# Altium schematic sheet 基于STM32的DDS信号源的设计实例.SchDoc
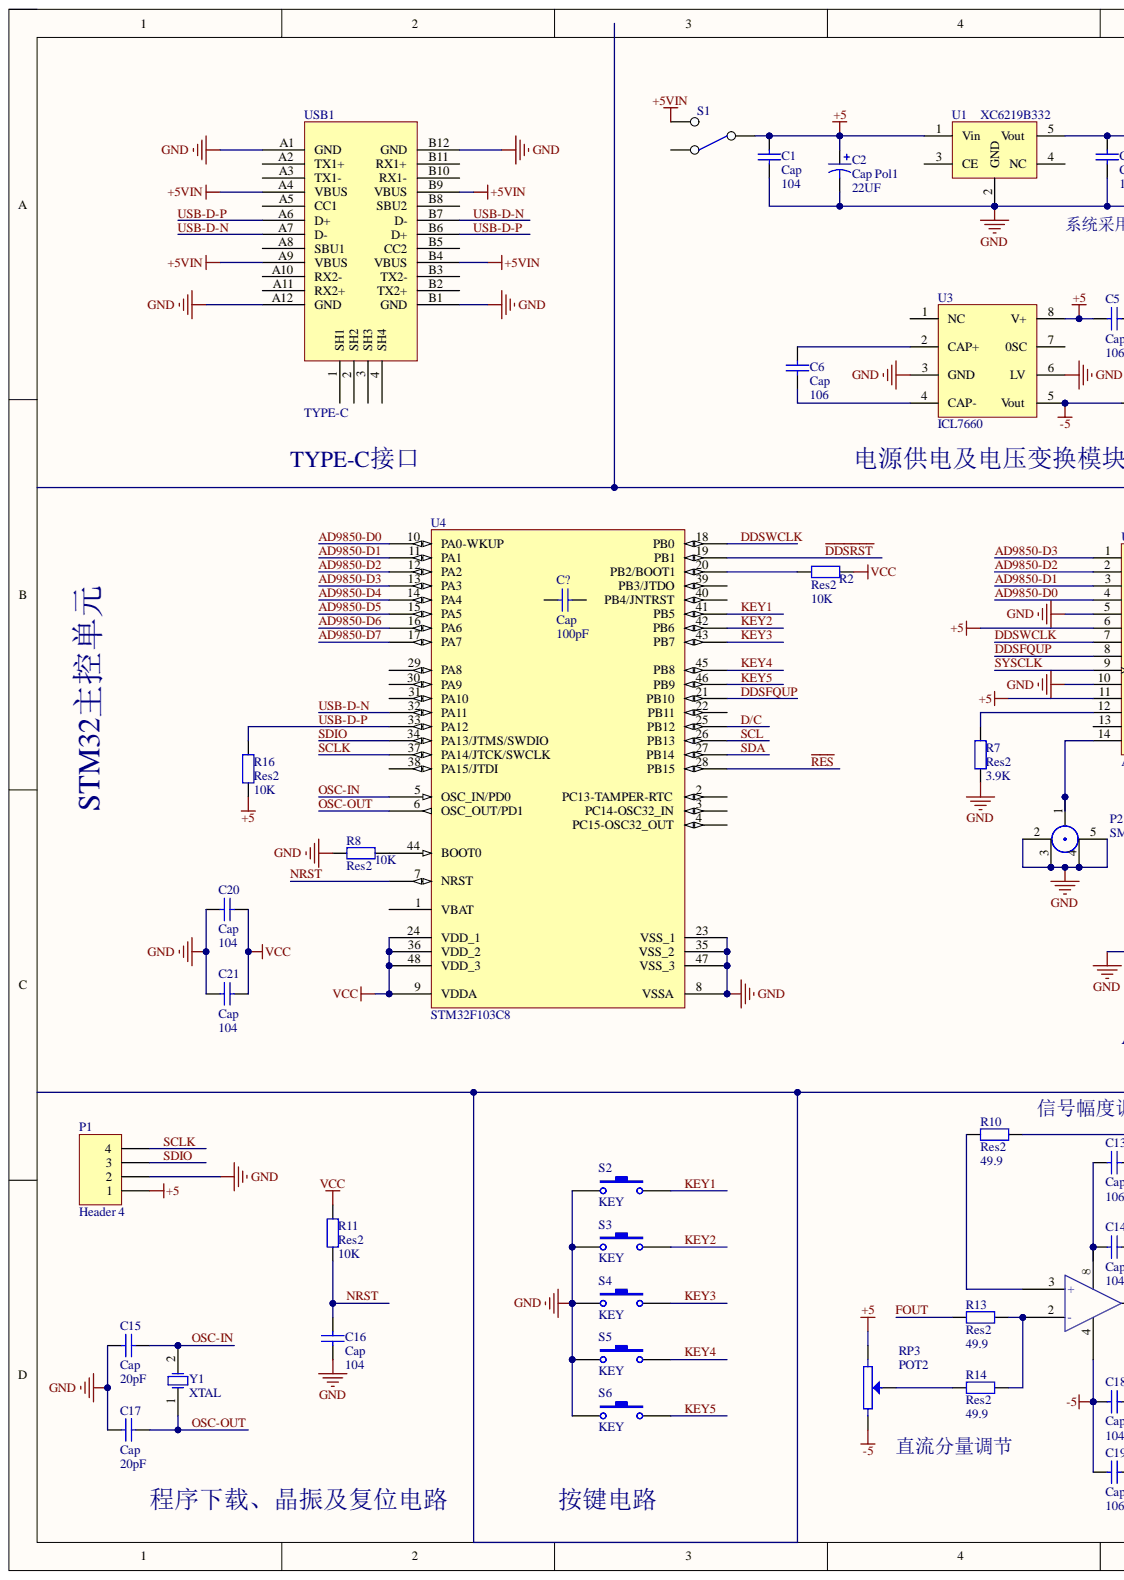
[diagram p0: 基于STM32的DDS信号源的设计实例.SchDoc, left]
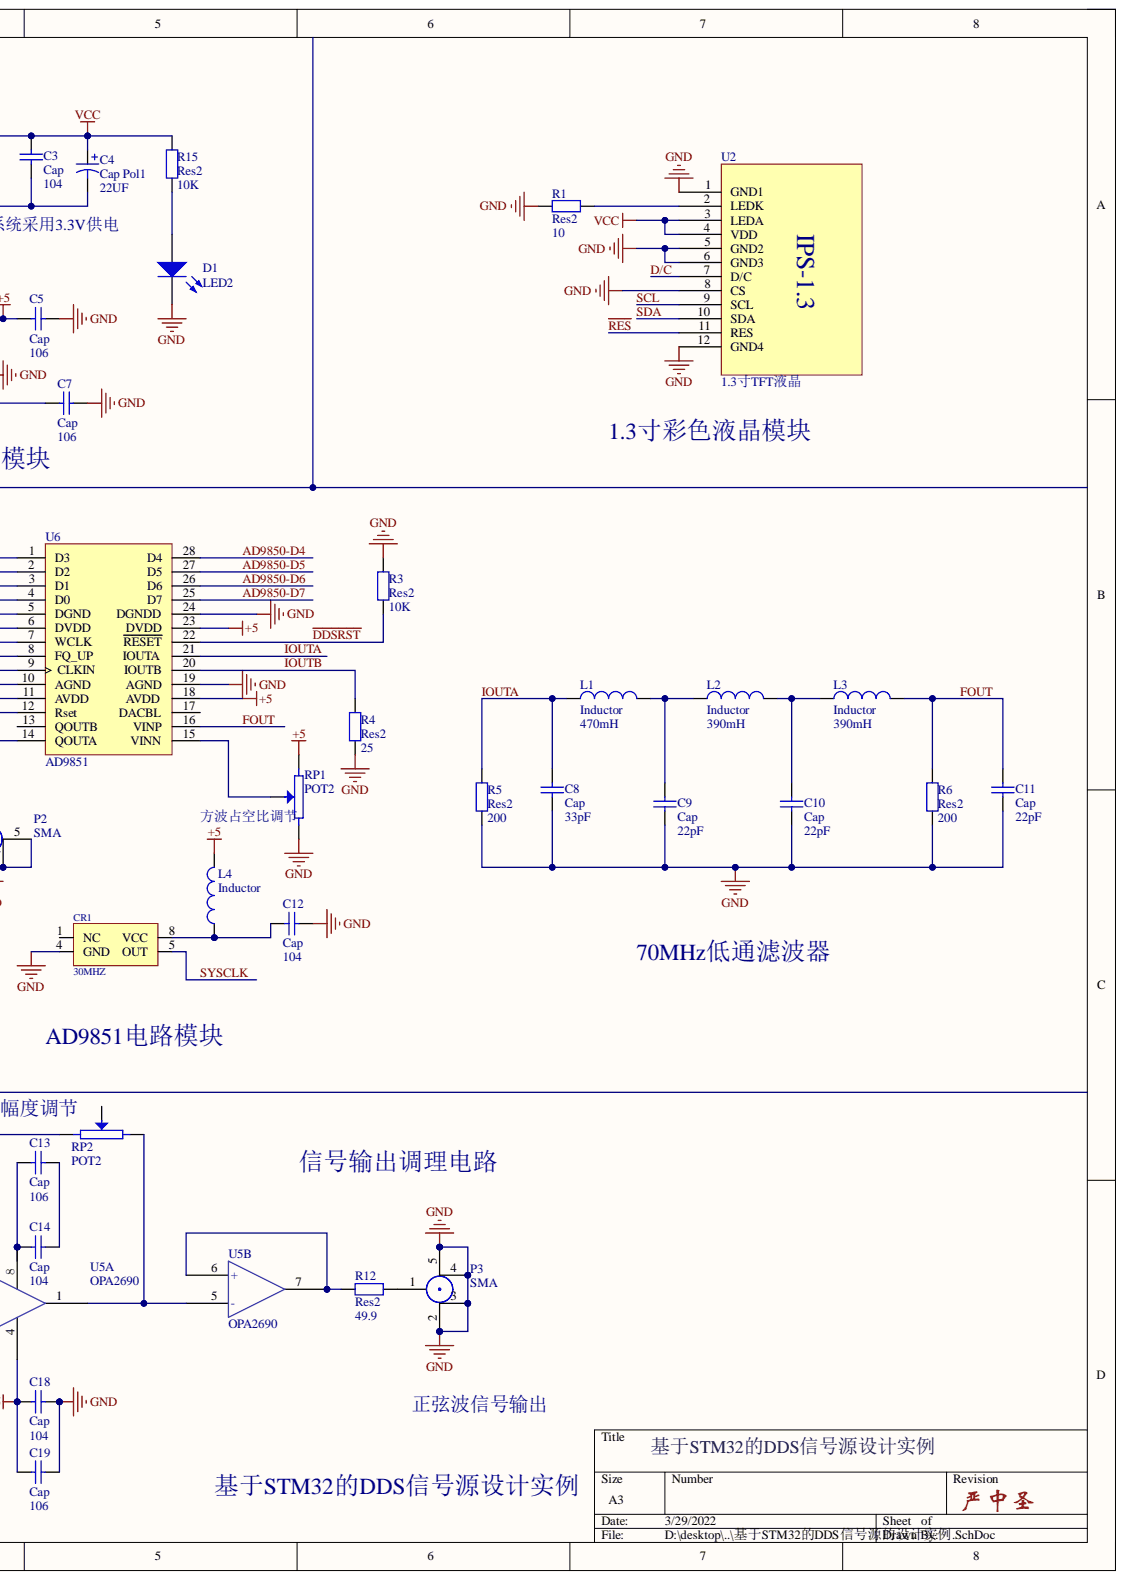
[diagram p1: 基于STM32的DDS信号源的设计实例.SchDoc, right]

## Parts
C1  Cap  value 104  [RAD-0.3]
C2  Cap Pol1  value 22UF  [RB7.6-15]
C3  Cap  value 104  [RAD-0.3]
C4  Cap Pol1  value 22UF  [RB7.6-15]
C5  Cap  value 106  [RAD-0.3]
C6  Cap  value 106  [RAD-0.3]
C7  Cap  value 106  [RAD-0.3]
C8  Cap  value 33pF  [RAD-0.3]
C9  Cap  value 22pF  [RAD-0.3]
C10  Cap  value 22pF  [RAD-0.3]
C11  Cap  value 22pF  [RAD-0.3]
C12  Cap  value 104  [RAD-0.3]
C13  Cap  value 106  [RAD-0.3]
C14  Cap  value 104  [RAD-0.3]
C15  Cap  value 20pF  [RAD-0.3]
C16  Cap  value 104  [RAD-0.3]
C17  Cap  value 20pF  [RAD-0.3]
C18  Cap  value 104  [RAD-0.3]
C19  Cap  value 106  [RAD-0.3]
C20  Cap  value 104  [RAD-0.3]
C21  Cap  value 104  [RAD-0.3]
C?  Cap  value 100pF  [RAD-0.3]
CR1  30MHZ  [CRYSTAL-SMT-5X7]
D1  LED2  [3.2X1.6X1.1]
L1  Inductor  value 470mH  [0402-A]
L2  Inductor  value 390mH  [0402-A]
L3  Inductor  value 390mH  [0402-A]
L4  Inductor  [6-0805_N]
P1  Header 4  [HDR1X4]
P2  SMA
P3  SMA
R1  Res2  value 10  [AXIAL-0.4]
R2  Res2  value 10K  [AXIAL-0.4]
R3  Res2  value 10K  [AXIAL-0.4]
R4  Res2  value 25  [AXIAL-0.4]
R5  Res2  value 200  [AXIAL-0.4]
R6  Res2  value 200  [AXIAL-0.4]
R7  Res2  value 3.9K  [AXIAL-0.4]
R8  Res2  value 10K  [AXIAL-0.4]
R10  Res2  value 49.9  [AXIAL-0.4]
R11  Res2  value 10K  [AXIAL-0.4]
R12  Res2  value 49.9  [AXIAL-0.4]
R13  Res2  value 49.9  [AXIAL-0.4]
R14  Res2  value 49.9  [AXIAL-0.4]
R15  Res2  value 10K  [AXIAL-0.4]
R16  Res2  value 10K  [AXIAL-0.4]
RP1  POT2  [VR7]
RP2  POT2  [VR7]
RP3  POT2  [VR7]
S1  (no value)  [SW-SPDT-7P]
S2  KEY  [SW-MINI]
S3  KEY  [SW-MINI]
S4  KEY  [SW-MINI]
S5  KEY  [SW-MINI]
S6  KEY  [SW-MINI]
U1  XC6219B332  [SOT23-5L]
U2  1.3寸TFT液晶  [1.3-TFT-TPS]
U3  ICL7660  [SO8_N]
U4  STM32F103C8  [TQFP-48]
U5  OPA2690  (parts A,B)
U6  AD9851
USB1  TYPE-C  [TYPE-C]
Y1  XTAL  [R38]

## Nets
+5: C1.2 C2.1 C5.1 L4.2 P1.1 R16.1 RP1.2 RP3.1 S1.2 U1.1(Vin) U3.8(V+) U6.6(DVDD) U6.11(AVDD) U6.18(AVDD) U6.23(DVDD)
+5VIN: S1.3 USB1.A4(VBUS) USB1.A9(VBUS) USB1.B4(VBUS) USB1.B9(VBUS)
-5: C7.1 C18.2 C19.2 RP3.2 U3.5(Vout) U5.4
GND: C1.1 C2.2 C3.1 C4.2 C5.2 C7.2 C8.1 C9.1 C10.1 C11.1 C12.2 C15.1 C16.1 C17.1 C18.1 C19.1 C20.1 C21.1 CR1.4(GND) D1.2 P1.2 P2.2 P2.3 P2.4 P2.5 P3.2 P3.3 P3.4 P3.5 R1.1 R3.2 R4.1 R5.1 R6.1 R7.1 R8.1 RP1.1 S2.1 S3.1 S4.1 S5.1 S6.1 U1.2(GND) U2.1(GND1) U2.5(GND2) U2.6(GND3) U2.8(CS) U2.12(GND4) U3.3(GND) U3.6(LV) U4.8(VSSA) U4.23(VSS_1) U4.35(VSS_2) U4.47(VSS_3) U6.5(DGND) U6.10(AGND) U6.19(AGND) U6.24(DGNDD) USB1.A1(GND) USB1.A12(GND) USB1.B1(GND) USB1.B12(GND)
VCC: C3.2 C4.1 C20.2 C21.2 R2.2 R11.2 R15.2 U1.5(Vout) U2.3(LEDA) U2.4(VDD) U4.9(VDDA) U4.24(VDD_1) U4.36(VDD_2) U4.48(VDD_3)
AD9850-D0: U4.10(PA0-WKUP) U6.4(D0)
AD9850-D1: U4.11(PA1) U6.3(D1)
AD9850-D2: U4.12(PA2) U6.2(D2)
AD9850-D3: U4.13(PA3) U6.1(D3)
AD9850-D4: U4.14(PA4) U6.28(D4)
AD9850-D5: U4.15(PA5) U6.27(D5)
AD9850-D6: U4.16(PA6) U6.26(D6)
AD9850-D7: U4.17(PA7) U6.25(D7)
D/C: U2.7(D/C) U4.25(PB12)
~{DDSRST}: R3.1 U4.19(PB1) U6.22(RESET)
DDSFQUP: U4.21(PB10) U6.8(FQ_UP)
DDSWCLK: U4.18(PB0) U6.7(WCLK)
FOUT: C11.2 L3.2 R6.2 R13.1 U6.16(VINP)
IOUTA: C8.2 L1.1 R5.2 U6.21(IOUTA)
IOUTB: R4.2 U6.20(IOUTB)
KEY1: S2.2 U4.41(PB5)
KEY2: S3.2 U4.42(PB6)
KEY3: S4.2 U4.43(PB7)
KEY4: S5.2 U4.45(PB8)
KEY5: S6.2 U4.46(PB9)
NRST: C16.2 R11.1 U4.7(NRST)
OSC-IN: C15.2 U4.5(OSC_IN/PD0) Y1.2
OSC-OUT: C17.2 U4.6(OSC_OUT/PD1) Y1.1
~{RES}: U2.11(RES) U4.28(PB15)
SCL: U2.9(SCL) U4.26(PB13)
SCLK: P1.4 U4.37(PA14/JTCK/SWCLK)
SDA: U2.10(SDA) U4.27(PB14)
SDIO: P1.3 U4.34(PA13/JTMS/SWDIO)
SYSCLK: CR1.5(OUT) U6.9(CLKIN)
USB-D-N: U4.32(PA11) USB1.A7(D-) USB1.B7(D-)
USB-D-P: R16.2 U4.33(PA12) USB1.A6(D+) USB1.B6(D+)
NetC6_1: C6.1 U3.2(CAP+)
NetC6_2: C6.2 U3.4(CAP-)
NetC9_2: C9.2 L1.2 L2.1
NetC10_2: C10.2 L2.2 L3.1
NetC12_1: C12.1 CR1.8(VCC) L4.1
NetC13_1: C13.1 C14.1
NetC13_2: C13.2 C14.2 U5.∞
NetD1_1: D1.1 R15.1
NetP2_1: P2.1 U6.14(QOUTA)
NetP3_1: P3.1 R12.2
NetR1_2: R1.2 U2.2(LEDK)
NetR2_1: R2.1 U4.20(PB2/BOOT1)
NetR7_2: R7.2 U6.12(Rset)
NetR8_2: R8.2 U4.44(BOOT0)
NetR10_1: R10.1 U5.3(+)
NetR10_2: R10.2 RP2.1
NetR12_1: R12.1 U5.6(+) U5.7
NetR13_2: R13.2 R14.2 U5.2(-)
NetR14_1: R14.1 RP3.3
NetRP1_3: RP1.3 U6.15(VINN)
NetRP2_2: RP2.2 U5.1 U5.5(-)

Not connected: C? 1 2; CR1 1(NC); RP2 3; S1 1; U1 3(CE) 4(NC); U3 1(NC) 7(0SC); U4 1(VBAT) 2(PC13-TAMPER-RTC) 3(PC14-OSC32_IN) 4(PC15-OSC32_OUT) 22(PB11) 29(PA8) 30(PA9) 31(PA10) 38(PA15/JTDI) 39(PB3/JTDO) 40(PB4/JNTRST); U6 13(QOUTB) 17(DACBL); USB1 1(SH1) 2(SH2) 3(SH3) 4(SH4) A2(TX1+) A3(TX1-) A5(CC1) A8(SBU1) A10(RX2-) A11(RX2+) B2(TX2+) B3(TX2-) B5(CC2) B8(SBU2) B10(RX1-) B11(RX1+)

## Library data of the parts
C1 C3 C5-C13 C15-C17 C19-C21 = Cap: Capacitor; Component Kind: Standard; LatestRevisionDate: 17-Jul-2002; LatestRevisionNote: Re-released for DXP Platform.; Library Name: Miscellaneous Devices.IntLib; PackageDescription: Radial Cap, Thru-Hole; 2 Leads; 0.3 in Pin Spacing; PackageReference: RAD-0.3; Published: 8-Jun-2000; Signal Integrity: Cap; Simulation: CAP; Publisher: Altium Limited
C2 C4 = Cap Pol1: Polarized Capacitor (Radial); Component Kind: Standard; LatestRevisionDate: 17-Jul-2002; LatestRevisionNote: Re-released for DXP Platform.; Library Name: Miscellaneous Devices.IntLib; PackageDescription: Polarized Capacitor (Radial); 2 Leads; PackageReference: RB7.6-15; Published: 8-Jun-2000; Signal Integrity: Cap Pol; Simulation: CAP; Publisher: Altium Limited
C14 C18 = Cap: Capacitor; Value: 104; Component Kind: Standard; LatestRevisionDate: 17-Jul-2002; LatestRevisionNote: Re-released for DXP Platform.; Library Name: Miscellaneous Devices.IntLib; PackageDescription: Radial Cap, Thru-Hole; 2 Leads; 0.3 in Pin Spacing; PackageReference: RAD-0.3; Published: 8-Jun-2000; Signal Integrity: Cap; Simulation: CAP; Publisher: Altium Limited
C? = Cap: Capacitor; Value: 100pF; Component Kind: Standard; LatestRevisionDate: 17-Jul-2002; LatestRevisionNote: Re-released for DXP Platform.; Library Name: Miscellaneous Devices.IntLib; PackageDescription: Radial Cap, Thru-Hole; 2 Leads; 0.3 in Pin Spacing; PackageReference: RAD-0.3; Published: 8-Jun-2000; Signal Integrity: Cap; Simulation: CAP; Publisher: Altium Limited
CR1 = CRYSTAL-DIP8: Component Kind: Standard; Library Name: 基于STM32的DDS信号源设计实例.IntLib
D1 = LED2: Typical RED, GREEN, YELLOW, AMBER GaAs LED; Component Kind: Standard; LatestRevisionDate: 17-Jul-2002; LatestRevisionNote: Re-released for DXP Platform.; Library Name: Miscellaneous Devices.IntLib; PackageDescription: SM LED; 2 Flat Leads; PackageReference: 3.2X1.6X1.1; PackageVersion: Feb-2002; Published: 8-Jun-2000; Simulation: LED2; Publisher: Altium Limited
L1-L4 = Inductor: Code_IEC: 1005; Code_JEITA: 0402; Component Kind: Standard; Description: Inductor; LatestRevisionDate: 29-May-2009; LatestRevisionNote: IPC-7351 Footprint Added.; PackageDescription: Chip Inductor; PackageReference: 0402-A; Published: 8-Jun-2000; Signal Integrity: Inductor; Simulation: INDUCTOR; Publisher: Altium Limited
  L1-L3: Library Name: Miscellaneous Devices.IntLib
  L4: Library Name: 基于STM32的DDS信号源设计实例.IntLib
P1 = Header 4: Header, 4-Pin; Component Kind: Standard; LatestRevisionDate: 17-Jul-2002; LatestRevisionNote: Re-released for DXP Platform.; Library Name: Miscellaneous Connectors.IntLib; Signal Integrity: Connector; Publisher: Altium Limited
R1-R8 R10-R16 = Res2: Resistor; Component Kind: Standard; LatestRevisionDate: 17-Jul-2002; LatestRevisionNote: Re-released for DXP Platform.; Library Name: Miscellaneous Devices.IntLib; PackageDescription: Axial Device, Thru-Hole; 2 Leads; 0.4 in Pin Spacing; PackageReference: AXIAL-0.4; Published: 8-Jun-2000; Signal Integrity: Res; Simulation: RESISTOR; Publisher: Altium Limited
RP1-RP3 = POT2: Potentiometer; Component Kind: Standard; Library Name: 基于STM32的DDS信号源设计实例.IntLib
S1 = SW SPDT: Component Kind: Standard; Library Name: 基于STM32的DDS信号源设计实例.IntLib
S2-S6 = SW-PB: Switch; Component Kind: Standard; LatestRevisionDate: 17-Jul-2002; LatestRevisionNote: Re-released for DXP Platform.; PackageDescription: Switch; 2 Leads; PackageReference: SPST-2; Published: 24-Mar-1999; Library Name: 基于STM32的DDS信号源设计实例.IntLib; Publisher: Altium Limited
U1 = XC6219: Component Kind: Standard; Library Name: 基于STM32的DDS信号源设计实例.IntLib
U4 = STM32F103C8: Flaah:64/RAM:20/IO:36; BOM名称: 32位STM单片机; 版权归属: 源创客; Component Kind: Standard; 学习资源: www.ooocke.com; Library Name: 基于STM32的DDS信号源设计实例.IntLib
Y1 = XTAL: Crystal Oscillator; Component Kind: Standard; LatestRevisionDate: 17-Jul-2002; LatestRevisionNote: Re-released for DXP Platform.; Library Name: Miscellaneous Devices.IntLib; PackageDescription: Crystal, Thru-Hole; 2 Leads; Body 3.1 x 8.2 mm (Dia.xH); PackageReference: R38; Published: 8-Jun-2000; Simulation: XTAL; Publisher: Altium Limited
P2 P3 = SMA: Component Kind: Standard; Library Name: 原理图库.SchLib
U2 = 1.3寸TFT液晶: Component Kind: Standard; Library Name: 基于STM32的DDS信号源设计实例.IntLib
U3 = ICL7660: Component Kind: Standard; Library Name: 基于STM32的DDS信号源设计实例.IntLib
U5 = OPA2690: Component Kind: Standard; Library Name: 原理图库.SchLib
U6 = AD9851: Component Kind: Standard; Library Name: 原理图库.SchLib
USB1 = TYPE-C: Component Kind: Standard; Library Name: 基于STM32的DDS信号源设计实例.IntLib
